# Altium schematic sheet CW313 20 Pin Connector.SchDoc
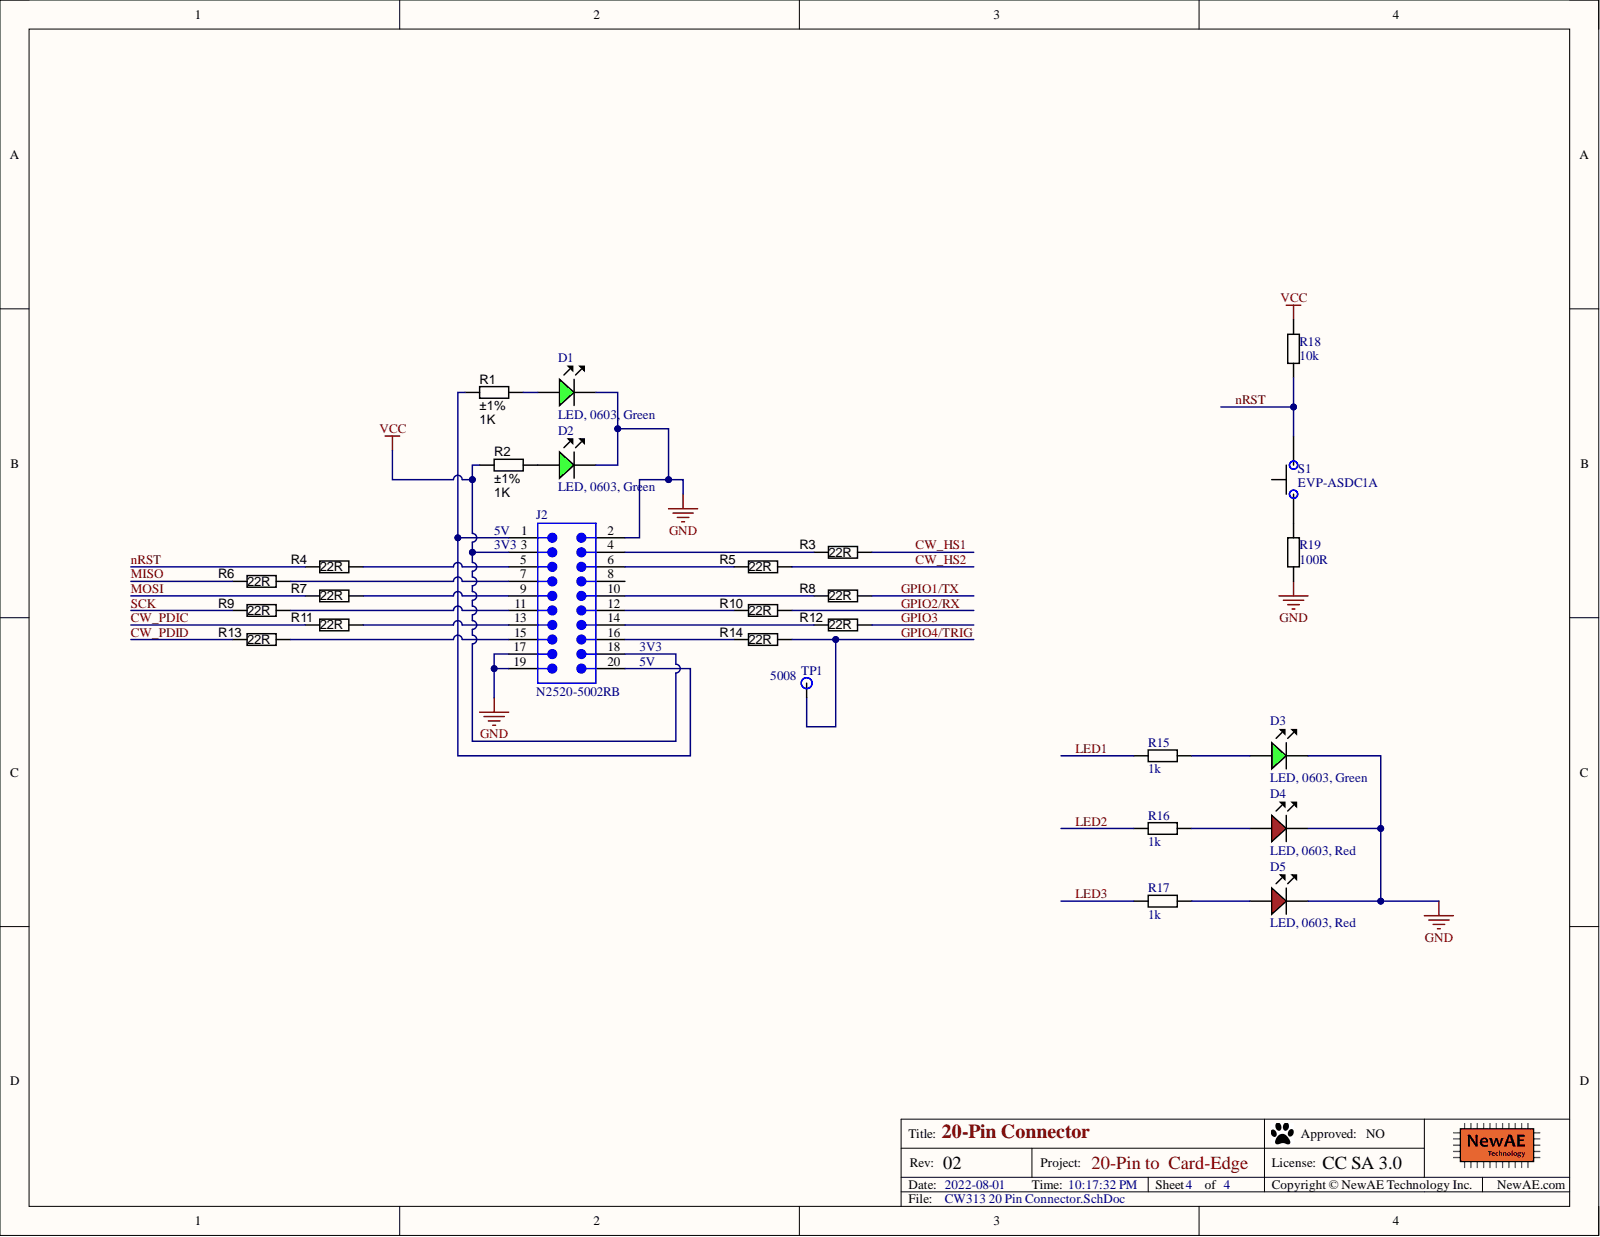
[diagram p0: CW313 20 Pin Connector.SchDoc]

## Parts
D1  LED, 0603, Green  [LED-0603-GREEN]
D2  LED, 0603, Green  [LED-0603-GREEN]
D3  LED, 0603, Green  [LED-0603-GREEN]
D4  LED, 0603, Red  [LED-0603-RED]
D5  LED, 0603, Red  [LED-0603-RED]
J2  N2520-5002RB  [NAE-PCB-CW-1000-0001-01]
R1  value 1K  Tolerance: ±1%  [RESC1608X55N]  (hidden comment: Thick Film)
R2  value 1K  Tolerance: ±1%  [RESC1608X55N]  (hidden comment: Thick Film)
R3  value 22R  [RESC1608X55N]  (hidden comment: Thick Film)
R4  value 22R  [RESC1608X55N]  (hidden comment: Thick Film)
R5  value 22R  [RESC1608X55N]  (hidden comment: Thick Film)
R6  value 22R  [RESC1608X55N]  (hidden comment: Thick Film)
R7  value 22R  [RESC1608X55N]  (hidden comment: Thick Film)
R8  value 22R  [RESC1608X55N]  (hidden comment: Thick Film)
R9  value 22R  [RESC1608X55N]  (hidden comment: Thick Film)
R10  value 22R  [RESC1608X55N]  (hidden comment: Thick Film)
R11  value 22R  [RESC1608X55N]  (hidden comment: Thick Film)
R12  value 22R  [RESC1608X55N]  (hidden comment: Thick Film)
R13  value 22R  [RESC1608X55N]  (hidden comment: Thick Film)
R14  value 22R  [RESC1608X55N]  (hidden comment: Thick Film)
R15  1k  [0603]
R16  1k  [0603]
R17  1k  [0603]
R18  10k  [0603]
R19  100R  [0603]
S1  EVP-ASDC1A  [EVPAS]
TP1  5008  [KEYSTONE5008]

## Nets
GND: D1.K D2.K D3.K D4.K D5.K J2.2 J2.17 J2.19 R19.2
VCC: J2.3 J2.18 R2.2 R18.1
CW_HS1: R3.2
CW_HS2: R5.2
CW_PDIC: R11.1
CW_PDID: R13.1
GPIO1/TX: R8.2
GPIO2/RX: R10.2
GPIO3: R12.2
GPIO4/TRIG: R14.2 TP1.1
LED1: R15.1
LED2: R16.1
LED3: R17.1
MISO: R6.1
MOSI: R7.1
nRST: R4.1 R18.2 S1.2
SCK: R9.1
NetD1_A: D1.A R1.1
NetD2_A: D2.A R2.1
NetD3_A: D3.A R15.2
NetD4_A: D4.A R16.2
NetD5_A: D5.A R17.2
NetJ2_1: J2.1 J2.20 R1.2
NetJ2_4: J2.4 R3.1
NetJ2_5: J2.5 R4.2
NetJ2_6: J2.6 R5.1
NetJ2_7: J2.7 R6.2
NetJ2_9: J2.9 R7.2
NetJ2_10: J2.10 R8.1
NetJ2_11: J2.11 R9.2
NetJ2_12: J2.12 R10.1
NetJ2_13: J2.13 R11.2
NetJ2_14: J2.14 R12.1
NetJ2_15: J2.15 R13.2
NetJ2_16: J2.16 R14.1
NetR19_1: R19.1 S1.1

Not connected: J2 8

## Library data of the parts
D1-D3 = LEDCHIP_0603_GREEN: Chip LED, Green; Manufacture 1: Kingbright; Manufacture Part Number 1: APT1608CGCK; Component Group: Optical; Database Table Name: main; PP Material Stack: 16; PP Rotation: -90; Component Kind: Standard; Component Type: Standard; Library Name: NewAE_AltiumLib.DBLib; Mounting Technology: SMT; Part Number: LEDCHIP_0603_GREEN; Pin Count: 2; Supplier 1: Digi-Key; Supplier Part Number 1: 754-1116-1-ND
D4 D5 = LEDCHIP_0603_RED: Chip LED, Red; Manufacture 1: Kingbright; Manufacture Part Number 1: APT1608SRCPRV; Component Group: Optical; Database Table Name: main; PP Material Stack: 15; PP Rotation: -90; Component Kind: Standard; Component Type: Standard; Library Name: NewAE_AltiumLib.DBLib; Mounting Technology: SMT; Part Number: LEDCHIP_0603_RED; Pin Count: 2; Supplier 1: Digi-Key; Supplier Part Number 1: 754-1122-1-ND
J2 = NAE-CW-1000-0001-01: 20 Pin R/A Shrouded Header; Manufacturer: 3M; Pitch: 2.54 mm; Component Kind: Standard; Library Name: NewAE_AltiumLib.SchLib; Mounting Technology: Through-hole; Orientation: Vertical; PackageDescription: .100[2.54] High Temp Terminal Body (Board Stacking); PackageReference: N2520-5002RB; PartNumber: N2520-5002RB; RoHS: True; Signal Integrity: Guessed Model; Supplier 1: Digi-Key; Supplier Part Number 1: MHE20K-ND; Temperature Range: -55 to 125 degC
R1 R2 = RES_1K_0603_1%: Resistor SMD chip 1k Ohm 0.1W 1% 0603; Manufacturer 1: Yageo; Manufacturer Part Number 1: RC0603FR-071KL; Ratings: 1/10W; SCDType: spec; Component Kind: Standard; Library Name: PBL - Resistors.SCHLIB; Package / Case: 0603 (1608 Metric); Supplier 1: Digi-Key; Supplier Part Number 1: 311-1.00KHRCT-ND
R3-R14 = RES_22R_0603_5%: Resistor SMD chip 22 Ohm 0.1W 5% 0603; Manufacturer 1: Yageo; Manufacturer Part Number 1: RC0603JR-0722RL; Ratings: 1/10W; SCDType: spec; Temperature Coefficient: ±100ppm/°C; Case: 0603 (1608 metric); Component Kind: Standard; ComponentLink1Description: http://www.yageo.com/documents/recent/PYu-RC0603_51_RoHS_L_4.pdf; ComponentLink1URL: http://www.yageo.com/documents/recent/PYu-RC0603_51_RoHS_L_4.pdf; ComponentLink2Description: http://www.samsungsem.com/servlet/FileDownload?filename=productcatalog/pdf/Chip_Resistor.pdf; ComponentLink2URL: http://www.samsungsem.com/servlet/FileDownload?filename=productcatalog/pdf/Chip_Resistor.pdf; ComponentLink3Description: http://www.seielect.com/Catalog/SEI-RMCF_RMCP.pdf; ComponentLink3URL: http://www.seielect.com/Catalog/SEI-RMCF_RMCP.pdf; ComponentLink4Description: http://rohmfs.rohm.com/en/products/databook/datasheet/passive/resistor/chip_resistor/mcr_g.pdf; ComponentLink4URL: http://rohmfs.rohm.com/en/products/databook/datasheet/passive/resistor/chip_resistor/mcr_g.pdf; ComponentLink5Description: http://industrial.panasonic.com/www-cgi/jvcr13pz.cgi?E+PZ+3+AOA0001+ERJ3GEYJ220V+7+WW; ComponentLink5URL: http://industrial.panasonic.com/www-cgi/jvcr13pz.cgi?E+PZ+3+AOA0001+ERJ3GEYJ220V+7+WW; Library Name: PBL - Resistors.SCHLIB; Manufacturer 2: Samsung Electro-Mechanics America, Inc; Manufacturer 3: Stackpole Electronics Inc; Manufacturer 4: Rohm Semiconductor; Manufacturer 5: Panasonic Electronic Components; Manufacturer Part Number 2: RC1608J220CS; Manufacturer Part Number 3: RMCF0603JT22R0; Manufacturer Part Number 4: MCR03ERTJ220; Manufacturer Part Number 5: ERJ-3GEYJ220V; Package / Case: 0603 (1608 metric); RoHS 1: RoHS Compliant; RoHS 2: RoHS Compliant; RoHS 3: RoHS Compliant; RoHS 4: RoHS Compliant; RoHS 5: RoHS Compliant; Supplier 1: Digi-Key; Supplier 2: Digi-Key; Supplier 3: Digi-Key; Supplier 4: Digi-Key; Supplier 5: Digi-Key; Supplier Part Number 1: 311-22GRCT-ND; Supplier Part Number 2: 1276-5022-6-ND; Supplier Part Number 3: RMCF0603JT22R0DKR-ND; Supplier Part Number 4: RHM22CGDKR-ND; Supplier Part Number 5: P22GDKR-ND; Tolerance: ±5%
R15-R17 = RCHIP-1K-0603: 1k res, 0603, 1%, 1/10W; Manufacture 1: Yageo; Manufacture Part Number 1: RC0603FR-071KL; Case-Metric: 1608; Component Group: Resistor; Database Table Name: main; Rated Power: 0.1W; Rated Voltage: 75VDC; Case-EIA: 0603; Component Kind: Standard; Component Type: Standard; Library Name: NewAE_AltiumLib.DBLib; Mounting Technology: SMT; Part Number: RCHIP-1K-0603; Pin Count: 2; Supplier 1: Digi-Key; Supplier Part Number 1: 311-1.00KHRCT-ND; Temperature Range: -55C to 155C; Tolerance: 1%; Value: 1k
R18 = RCHIP-10K-0603: 10k res, 0603, 1%, 1/10W; Manufacture 1: Yageo; Manufacture Part Number 1: RC0603FR-0710KL; Case-Metric: 1608; Component Group: Resistor; Database Table Name: main; Rated Power: 0.1W; Rated Voltage: 75VDC; Case-EIA: 0603; Component Kind: Standard; Component Type: Standard; Library Name: NewAE_AltiumLib.DBLib; Mounting Technology: SMT; Part Number: RCHIP-10K-0603; Pin Count: 2; Supplier 1: Digi-Key; Supplier Part Number 1: 311-10.0KHRCT-ND; Temperature Range: -55C to 155C; Tolerance: 1%; Value: 10k
R19 = RCHIP-100R-0603: 100R res, 0603, 1%, 1/10W; Manufacture 1: Yageo; Manufacture Part Number 1: RC0603FR-07100RL; Case-Metric: 1608; Component Group: Resistor; Database Table Name: main; Rated Power: 0.1W; Rated Voltage: 75VDC; Case-EIA: 0603; Component Kind: Standard; Component Type: Standard; Library Name: NewAE_AltiumLib.DBLib; Mounting Technology: SMT; Part Number: RCHIP-100R-0603; Pin Count: 2; Supplier 1: Digi-Key; Supplier Part Number 1: 311-100HRCT-ND; Temperature Range: -55C to 155C; Tolerance: 1%; Value: 100R
S1 = EVP-ASDC1A: Pushbutton, SMD, 2.5NM force, NO; Manufacture 1: Panasonic; Component Group: Switch; Database Table Name: main; Rated Power: 0.1W; Rated Voltage: 75VDC; Component Kind: Standard; Component Type: Standard; Library Name: NewAE_AltiumLib.DBLib; Manufacture Part Number 1: EVP-ASDC1A; Mounting Technology: SMT; Part Number: EVP-ASDC1A; Pin Count: 2; Supplier 1: Digi-Key; Supplier Part Number 1: P16856CT-ND
TP1 = KEYSTONE_5008: TEST POINT PC COMPACT .063"D ORG; Database Table Name: main; Component Group: Test Point; Component Kind: Standard; Component Type: Standard; Library Name: NewAE_AltiumLib.DBLib; Mounting Technology: Through-hole; Part Number: KEYSTONE_5008; Pin Count: 1; Supplier 1: Digi-Key; Supplier Part Number 1: 36-5008-ND
